# Altium schematic sheet main.SchDoc(main)
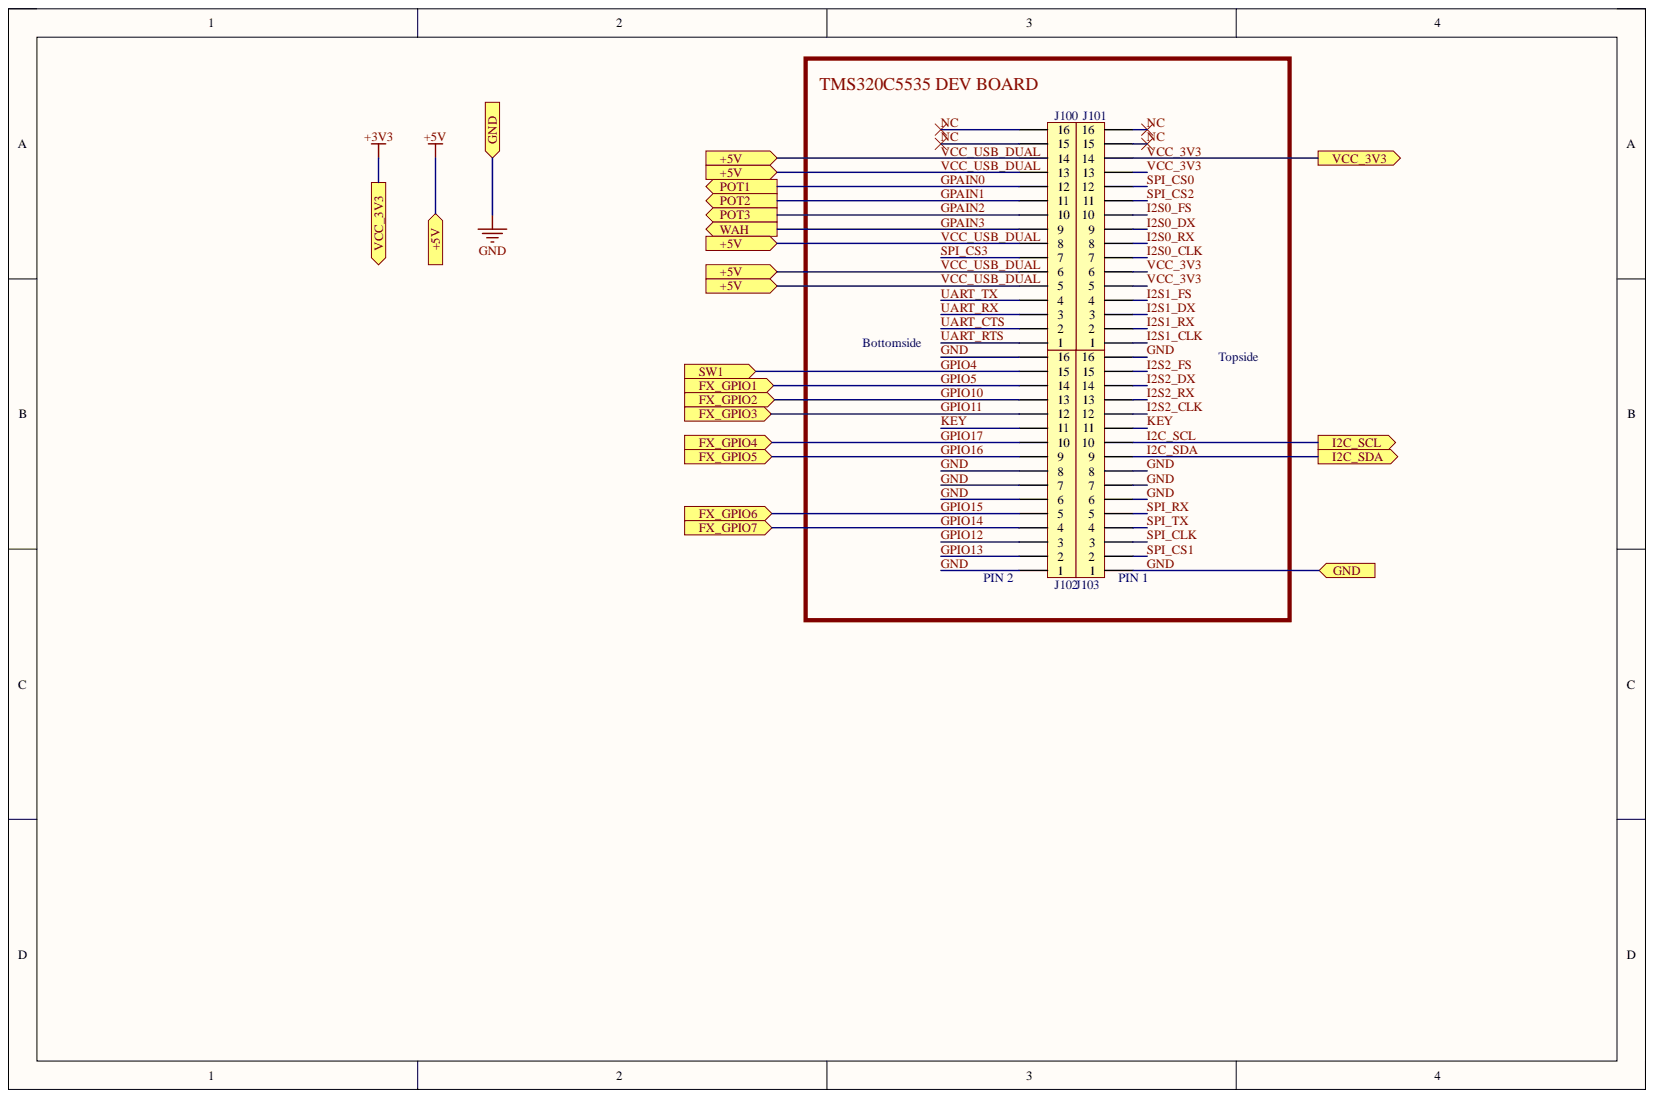
[diagram p0: main.SchDoc]

## Parts
J100  Header 1x16  [Header 1x16]  (value not drawn)
J101  Header 1x16  [Header 1x16]  (value not drawn)
J102  Header 1x16  [Header 1x16]  (value not drawn)
J103  Header 1x16  [Header 1x16]  (value not drawn)

## Ports
+5V, FX_GPIO1, FX_GPIO2, FX_GPIO3, FX_GPIO4, FX_GPIO5, FX_GPIO6, FX_GPIO7, GND, I2C_SCL, I2C_SDA, POT1, POT2, POT3, SW1, VCC_3V3, WAH

## Nets
GND: J102.1 J102.6 J102.7 J102.8 J102.16 J103.1 J103.6 J103.7 J103.8 J103.16 ; port GND
GPAIN0: J100.12 ; port POT1
GPAIN1: J100.11 ; port POT2
GPAIN2: J100.10 ; port POT3
GPAIN3: J100.9 ; port WAH
GPIO4: J102.15 ; port SW1
GPIO5: J102.14 ; port FX_GPIO1
GPIO10: J102.13 ; port FX_GPIO2
GPIO11: J102.12 ; port FX_GPIO3
GPIO12: J102.3
GPIO13: J102.2
GPIO14: J102.4 ; port FX_GPIO7
GPIO15: J102.5 ; port FX_GPIO6
GPIO16: J102.9 ; port FX_GPIO5
GPIO17: J102.10 ; port FX_GPIO4
I2C_SCL: J103.10 ; port I2C_SCL
I2C_SDA: J103.9 ; port I2C_SDA
I2S0_CLK: J101.7
I2S0_DX: J101.9
I2S0_FS: J101.10
I2S0_RX: J101.8
I2S1_CLK: J101.1
I2S1_DX: J101.3
I2S1_FS: J101.4
I2S1_RX: J101.2
I2S2_CLK: J103.12
I2S2_DX: J103.14
I2S2_FS: J103.15
I2S2_RX: J103.13
KEY: J102.11 J103.11
NC: J100.15 J100.16 J101.15 J101.16
SPI_CLK: J103.3
SPI_CS0: J101.12
SPI_CS1: J103.2
SPI_CS2: J101.11
SPI_CS3: J100.7
SPI_RX: J103.5
SPI_TX: J103.4
UART_CTS: J100.2
UART_RTS: J100.1
UART_RX: J100.3
UART_TX: J100.4
VCC_3V3: J101.5 J101.6 J101.13 J101.14 ; port VCC_3V3
VCC_USB_DUAL: J100.5 J100.6 J100.8 J100.13 J100.14 ; port +5V

## Library data of the parts
J100-J103 = Header 1x16: Component Kind: Standard; Library Name: AAURacing.IntLib
